# Altium schematic sheet POWER.SchDoc
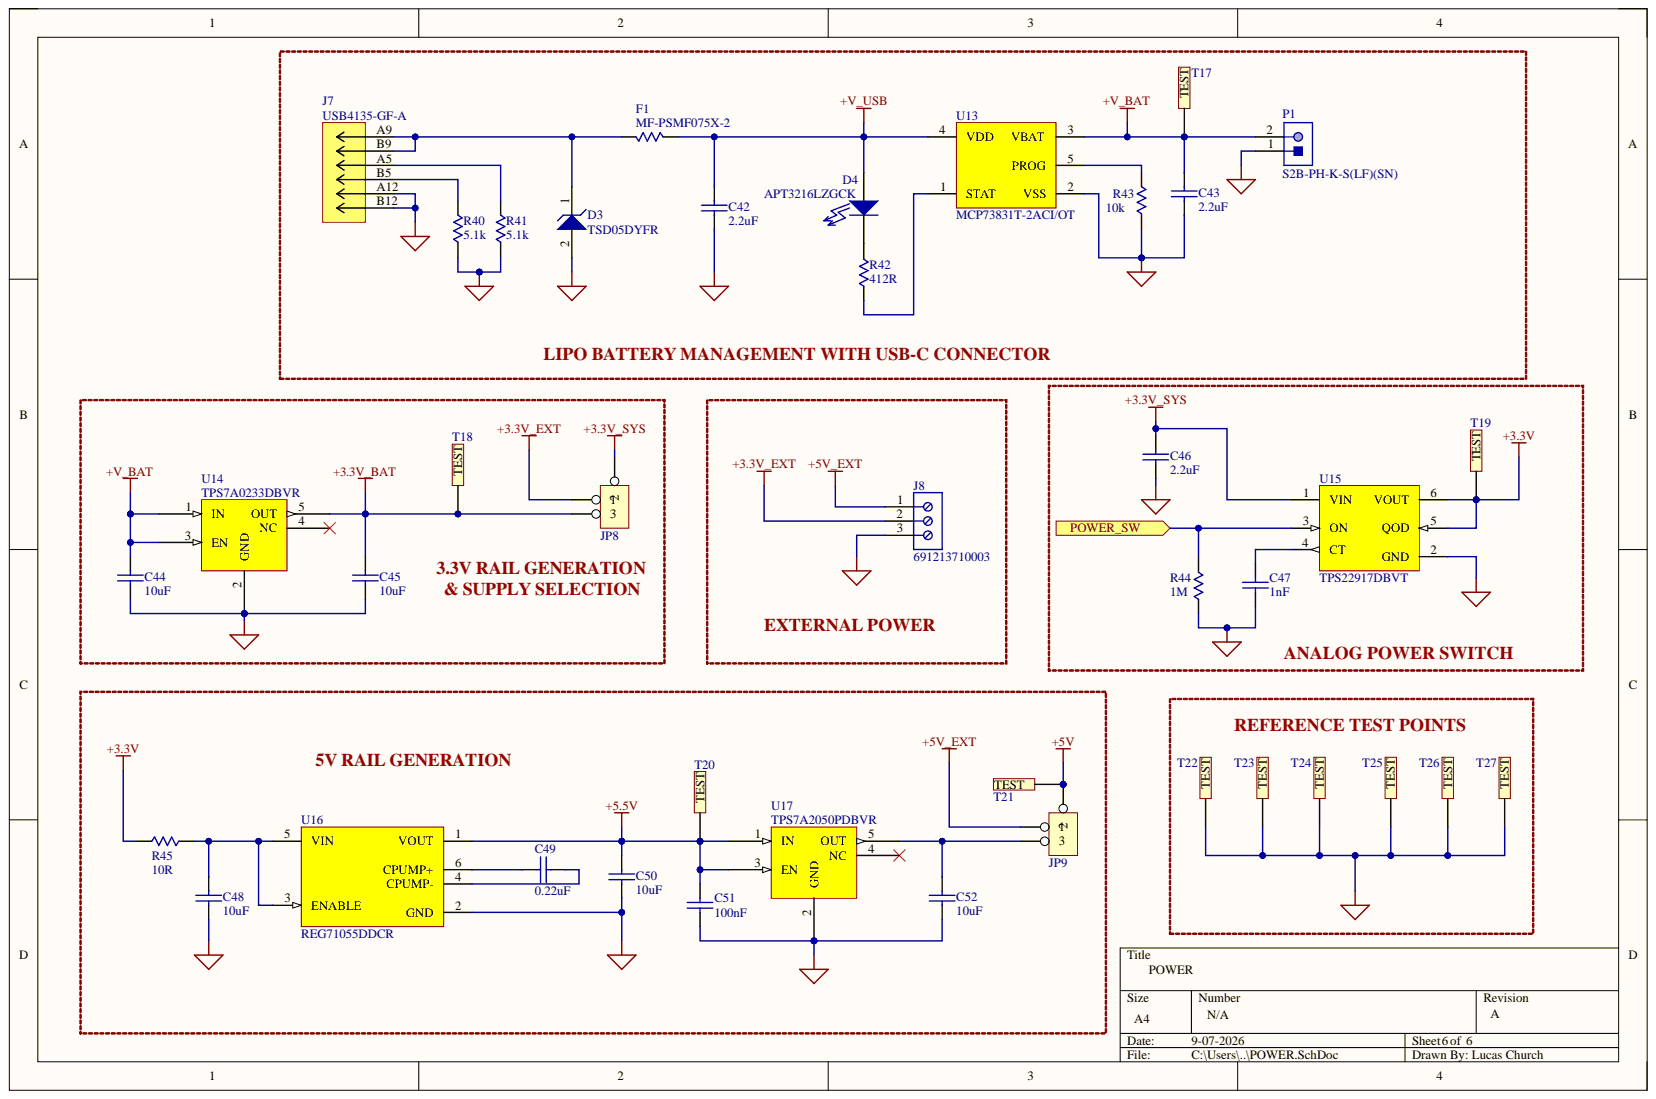
[diagram p0: POWER.SchDoc]

## Parts
C42  2.2uF  [0603-CAP]
C43  2.2uF  [0603-CAP]
C44  10uF  [0603-CAP]
C45  10uF  [0603-CAP]
C46  2.2uF  [0603-CAP]
C47  1nF  [0603-CAP]
C48  10uF  [0603-CAP]
C49  0.22uF  [0603-CAP]
C50  10uF  [0603-CAP]
C51  100nF  [0603-CAP]
C52  10uF  [0603-CAP]
D3  TSD05DYFR  [TVS_SOD2_DYF_TEX-L]
D4  APT3216LZGCK  [1206-LED]
F1  MF-PSMF075X-2  [0805-RES]
J7  USB4135-GF-A  [CONN_USB4135-GF-A_GCT]
J8  691213710003  [691213710003]
JP8  JUMPER1X3  [JUMPER3]  (value not drawn)
JP9  JUMPER1X3  [JUMPER3]  (value not drawn)
P1  S2B-PH-K-S(LF)(SN)  [JST-S2B-PH-K-S_V]
R40  5.1k  [0603-RES]
R41  5.1k  [0603-RES]
R42  412R  [0603-RES]
R43  10k  [0603-RES]
R44  1M  [0603-RES]
R45  10R  [0603-RES]
T17  5002K  [TESTPOINT White]  (value not drawn)
T18  5002K  [TESTPOINT White]  (value not drawn)
T19  5002K  [TESTPOINT White]  (value not drawn)
T20  5002K  [TESTPOINT White]  (value not drawn)
T21  5002K  [TESTPOINT White]  (value not drawn)
T22  5011K  [TESTPOINT Black]  (value not drawn)
T23  5011K  [TESTPOINT Black]  (value not drawn)
T24  5011K  [TESTPOINT Black]  (value not drawn)
T25  5011K  [TESTPOINT Black]  (value not drawn)
T26  5011K  [TESTPOINT Black]  (value not drawn)
T27  5011K  [TESTPOINT Black]  (value not drawn)
U13  MCP73831T-2ACI/OT  [SOT23_DBV_TEX-M]
U14  TPS7A0233DBVR  [SOT23_DBV_TEX-M]
U15  TPS22917DBVT  [DBV0006_N]
U16  REG71055DDCR  [DDC0006A_M]
U17  TPS7A2050PDBVR  [SOT23_DBV_TEX-M]

## Ports
POWER_SW

## Nets
+3.3V: R45.1 T19.1 U15.5(QOD) U15.6(VOUT)
+3.3V_BAT: C45.1 JP8.3 T18.1 U14.5(OUT)
+3.3V_EXT: J8.2 JP8.1
+3.3V_SYS: C46.1 JP8.2 U15.1(VIN)
+5.5V: C50.1 C51.1 T20.1 U16.1(VOUT) U17.1(IN) U17.3(EN)
+5V: JP9.2 T21.1
+5V_EXT: J8.1 JP9.1
+V_BAT: C43.1 C44.1 P1.2 T17.1 U13.3(VBAT) U14.1(IN) U14.3(EN)
+V_USB: C42.1 D4.1 F1.2 U13.4(VDD)
GND: C42.2 C43.2 C44.2 C45.2 C46.2 C47.2 C48.2 C50.2 C51.2 C52.2 D3.2 J7.A12 J7.B12 J8.3 P1.1 R40.2 R41.2 R43.2 R44.1 T22.1 T23.1 T24.1 T25.1 T26.1 T27.1 U13.2(VSS) U14.2(GND) U15.2(GND) U16.2(GND) U17.2(GND)
POWER_SW: R44.2 U15.3(ON) ; port POWER_SW
NetC47_1: C47.1 U15.4(CT)
NetC48_1: C48.1 R45.2 U16.3(ENABLE) U16.5(VIN)
NetC49_1: C49.1 U16.6(CPUMP+)
NetC49_2: C49.2 U16.4(CPUMP-)
NetC52_1: C52.1 JP9.3 U17.5(OUT)
NetD3_1: D3.1 F1.1 J7.A9 J7.B9
NetD4_2: D4.2 R42.2
NetJ7_A5: J7.A5 R41.1
NetJ7_B5: J7.B5 R40.1
NetR42_1: R42.1 U13.1(STAT)
NetR43_1: R43.1 U13.5(PROG)
NetU14_4: U14.4(NC)
NetU17_4: U17.4(NC)

## Library data of the parts
C42 C43 C46 = CGA3E2C0G1H472J080AA: CAP CER 2.2UF 35V X5R 0603; Manufacturer 1: Murata Electronics; Manufacturer Part Number 1: GRM188R6YA225MA12D; Database Table Name: Ceramic 0603$; Tech#: SMT; Component Kind: Standard; Detailed Description: CAP CER 2.2UF 35V X5R 0603; Price(100): 0.1434; Price(250): 0.10756; Supplier 1: DigiKey; Supplier 2: Mouser; Supplier Part Number 1: 490-10486-1-ND; Supplier Part Number 2: 810-CGA3E2C0G1H472J; Library Name: SGL_Capacitors.DBLib
C44 C45 = CGA3E2C0G1H472J080AA: CAP CER 10uF 6.3V X5R 0603; Manufacturer 1: Murata Electronics; Manufacturer Part Number 1: GRM188Z71A106KA73D; Database Table Name: Ceramic 0603$; Detailed Description: CAP CER 100 NF 50V X7R 0603; Tech#: SMT; Component Kind: Standard; Price(100): 0.1434; Price(250): 0.10756; Supplier 1: DigiKey; Supplier 2: Mouser; Supplier Part Number 1: 490-GRM188Z71A106KA73DCT-ND; Supplier Part Number 2: 810-CGA3E2C0G1H472J; Library Name: SGL_Capacitors.DBLib
C47 = CGA3E2C0G1H472J080AA: CAP CER 1000 PF 50V C0G 0603; Manufacturer 1: Murata; Manufacturer Part Number 1: GRM1885C1H102JA01D; Database Table Name: Ceramic 0603$; Detailed Description: CAP CER 100 NF 50V X7R 0603; Tech#: SMT; Component Kind: Standard; Price(100): 0.1434; Price(250): 0.10756; Supplier 1: DigiKey; Supplier 2: Mouser; Supplier Part Number 1: 490-1451-1-ND; Supplier Part Number 2: 810-CGA3E2C0G1H472J; Library Name: SGL_Capacitors.DBLib
C48 C52 = CGA3E2C0G1H472J080AA: CAP CER 10UF 10V X7R 0603; Manufacturer 1: Murata Electronics; Manufacturer Part Number 1: GRM188Z71A106KA73D; Database Table Name: Ceramic 0603$; Tech#: SMT; Component Kind: Standard; Detailed Description: CAP CER 10UF 10V X7R 0603; Price(100): 0.1434; Price(250): 0.10756; Supplier 1: DigiKey; Supplier 2: Mouser; Supplier Part Number 1: 490-GRM188Z71A106KA73DCT-ND; Supplier Part Number 2: 810-CGA3E2C0G1H472J; Library Name: SGL_Capacitors.DBLib
C49 = CGA3E2C0G1H472J080AA: CAP CER 0.22UF 25V X7R 0603; Manufacturer 1: Murata Electronics; Manufacturer Part Number 1: GRM188R71E224KA88D; Database Table Name: Ceramic 0603$; Tech#: SMT; Component Kind: Standard; Detailed Description: CAP CER 0.22UF 25V X7R 0603; Price(100): 0.1434; Price(250): 0.10756; Supplier 1: DigiKey; Supplier 2: Mouser; Supplier Part Number 1: 490-3290-1-ND; Supplier Part Number 2: 810-CGA3E2C0G1H472J; Library Name: SGL_Capacitors.DBLib
C50 = CGA3E2C0G1H472J080AA: CAP CER 10UF 10V X7R 0603; Manufacturer 1: Murata Electronics; Manufacturer Part Number 1: GRM188Z71A106KA73D; Database Table Name: Ceramic 0603$; Detailed Description: CAP CER 100 NF 50V X7R 0603; Tech#: SMT; Component Kind: Standard; Price(100): 0.1434; Price(250): 0.10756; Supplier 1: DigiKey; Supplier 2: Mouser; Supplier Part Number 1: 490-GRM188Z71A106KA73DCT-ND; Supplier Part Number 2: 810-CGA3E2C0G1H472J; Library Name: SGL_Capacitors.DBLib
C51 = CGA3E2C0G1H472J080AA: CAP CER 100 NF 50V X7R 0603; Manufacturer 1: YAGEO; Manufacturer Part Number 1: CC0603KRX7R9BB104; Database Table Name: Ceramic 0603$; Detailed Description: CAP CER 10UF 10V X7R 0603; Tech#: SMT; Component Kind: Standard; Price(100): 0.1434; Price(250): 0.10756; Supplier 1: DigiKey; Supplier 2: Mouser; Supplier Part Number 1: 311-1344-1-ND; Supplier Part Number 2: 810-CGA3E2C0G1H472J; Library Name: SGL_Capacitors.DBLib
D3 = TSD05DYFR: 5-V 60-A Unidirectional surge protection device 2-SOT -40 to 125; Manufacturer_Name: Texas Instruments; Component Kind: Standard; Manufacturer_Part_Number: TSD05DYFR; RefDes: RefDes; Type: Type; Copyright: Copyright (C) 2026 Ultra Librarian. All rights reserved.; Library Name: BioZ.SchLib
D4 = APT3216LZGCK: LED GREEN CLEAR 2SMD; Manufacturer 1: Kingbright; Database Table Name: LEDs$; Detailed Description: LED, Green SMT1206 2.65V 2mA 50mcd; Location: #N/A; Spec: 2.65V 2mA 50mcd; Tech#: SMT; Colour: Green; Component Kind: Standard; Manufacturer Part Number 1: APT3216LZGCK; Price(1): 0.94; Price(10): 0.725; Price(25): 0.6076; Price(100): 0.5233; Supplier 1: DigiKey; Supplier 2: Mouser; Supplier Part Number 1: 754-1944-1-ND; Supplier Part Number 2: 604-APT3216LZGCK; Library Name: SGL_Diodes.DBLib
F1 = 240R 0.1%: PTC RESET FUSE 6V 750MA 0805; Manufacturer 1: Bourns; Database Table Name: 0805-tenth%$; Tech#: SMT; Component Kind: Standard; Detailed Description: PTC RESET FUSE 6V 750MA 0805; Manufacturer Part Number 1: MF-PSMF075X-2; Price(100): 0.149; Simulation: Resistor; Supplier 1: Digikey; Supplier 2: DigiKey; Supplier Part Number 1: MF-PSMF075X-2CT-ND; Supplier Part Number 2: RG20P240BCT-ND; Library Name: SGL_Resistives.DBLib
J7 = USB4135-GF-A: Description; Manufacturer_Name: Global Connector Technology; Component Kind: Standard; Manufacturer_Part_Number: USB4135-GF-A; RefDes: RefDes; Type: Type; Copyright: Copyright (C) 2026 Ultra Librarian. All rights reserved.; Library Name: BioZ.SchLib
J8 = CMP-1502-02527-3: 213 Series 5MM Pitch Straight, Pcb Terminal Block, Through Hole, 3 Way; Connector Type: PCB, Terminal Block; Current: 10A; Number of Contacts: 3; Number of Levels: 1; Pitch: 5.0038mm; Plating: Brass, Steel; Stripping Length: -7mm; Voltage: 300V; Wire Gauge (Max): 26AWG; Wire Gauge (Min): 16AWG; Color: Green; Component Kind: Standard; Contact Material: Brass; Contact Plating: Tin; Height: 10mm; Housing Material: Nylon; Lead Pitch: 5mm; Length: 8.3mm; Library Name: Altium Content Vault; Max Operating Temperature: 105°C; Min Operating Temperature: -40°C; Mount: Through Hole; Number of Pins: 3; Number of Positions Per Level: 3; Packaging: Box; REACH SVHC: Unknown; RoHS: Compliant; Termination: Screw; Width: 15.6mm; Wire/Cable Gauge: 16AWG
JP8 JP9 = 68001-203HLF: CONN HEADER VERT 36POS 2.54MM; Manufacturer 1: FCI; Database Table Name: Jumpers$; Detailed Description: Jumper, 1x3 Vertical T/H 0.1in; Location: #N/A; Notes: Can be 68001-236HLF cut to length; Tech#: T/H; Component Kind: Standard; Manufacturer Part Number 1: 68001-203HLF; Price(1): 0.43; Price(10): 0.32; Price(25): 0.32; Price(100): 0.2436; Supplier 1: DigiKey; Supplier 2: Mouser; Supplier Part Number 1: 609-3470-ND; Supplier Part Number 2: 649-68001-203HLF; Library Name: SGL_Connectors.DBLib
P1 = CMP-1755-00004-1: Male Header, Pitch 2 mm, 1 x 2 Position, Height 4.8 mm, Tail Length 3.4 mm, -25 to 85 degC, RoHS, Bulk; Capacitance: 10 uF; Case\Package: Radial; Tolerance: 20%; Voltage Rating: 50 V; Component Kind: Standard; ComponentLink1Description: Datasheet; ComponentLink1URL: http://www.jst-mfg.com/product/pdf/eng/ePH.pdf; DatasheetVersion: Dec-12; Diameter: 5 mm; Height: 11 mm; Lead Pitch: 2 mm; Length: 11 mm; Library Name: Altium Content Vault; Max Operating Temperature: 85 degC; Min Operating Temperature: -40 degC; Mount: Through Hole; Package Quantity: 200; PackageDescription: Male Header, Pitch 2 mm, 1 x 2 Position, Height 4.8 mm, Tail Length 3.4 mm; PackageReference: S2B-PH-K-S; Packaging: Bulk; Pins: 2; Radiation Hardening: No; REACH SVHC: No SVHC; RoHSCompliant: true; Voltage Rating (DC): 50 V
R40 R41 = 4.99K 0603: RES SMD 5.1K OHM 0.1% 1/10W 0603; Manufacturer 1: Susumu; Manufacturer Part Number 1: RG1608P-512-B-T5; Database Table Name: 0603$; Tech#: SMT; Component Kind: Standard; Detailed Description: RES SMD 5.1K OHM 0.1% 1/10W 0603; Price(100): 0.023; Simulation: Resistor; Supplier 1: DigiKey; Supplier 2: Mouser; Supplier Part Number 1: RG16P5.1KBCT-ND; Supplier Part Number 2: 754-RR0816P-4991D68H; Library Name: SGL_Resistives.DBLib
R42 = 4.99K 0603: RES SMD 412OHM 0.1% 1/10W 0603; Manufacturer 1: YAGEO; Manufacturer Part Number 1: RT0603BRD07412RL; Database Table Name: 0603$; Tech#: SMT; Component Kind: Standard; Detailed Description: RES SMD 412OHM 0.1% 1/10W 0603; Price(100): 0.023; Simulation: Resistor; Supplier 1: DigiKey; Supplier 2: Mouser; Supplier Part Number 1: YAG1659CT-ND; Supplier Part Number 2: 754-RR0816P-4991D68H; Library Name: SGL_Resistives.DBLib
R43 = 4.99K 0603: RES SMD 10K OHM 1% 1/10W 0603; Manufacturer 1: Panasonic Industry; Manufacturer Part Number 1: ERJ-3EKF1002V; Database Table Name: 0603$; Tech#: SMT; Component Kind: Standard; Detailed Description: RES SMD 10K OHM 1% 1/10W 0603; Price(100): 0.023; Simulation: Resistor; Supplier 1: DigiKey; Supplier 2: Mouser; Supplier Part Number 1: P10.0KHCT-ND; Supplier Part Number 2: 754-RR0816P-4991D68H; Library Name: SGL_Resistives.DBLib
R44 = 4.99K 0603: RES SMD 1MOHM 0.1% 1/10W 0603; Manufacturer 1: YAGEO; Manufacturer Part Number 1: RT0603BRD071ML; Database Table Name: 0603$; Detailed Description: RES SMD 1OHM 0.1% 1/10W 0603; Tech#: SMT; Component Kind: Standard; Price(100): 0.023; Simulation: Resistor; Supplier 1: DigiKey; Supplier 2: Mouser; Supplier Part Number 1: YAG4498CT-ND; Supplier Part Number 2: 754-RR0816P-4991D68H; Library Name: SGL_Resistives.DBLib
R45 = 4.99K 0603: RES SMD 10OHM 0.05% 1/10W 0603; Manufacturer 1: Stackpole Electronics; Manufacturer Part Number 1: RNCF0603AKT10R0; Database Table Name: 0603$; Tech#: SMT; Component Kind: Standard; Detailed Description: RES SMD 10OHM 0.05% 1/10W 0603; Price(100): 0.023; Simulation: Resistor; Supplier 1: DigiKey; Supplier 2: Mouser; Supplier Part Number 1: RNCF0603AKT10R0CT-ND; Supplier Part Number 2: 754-RR0816P-4991D68H; Library Name: SGL_Resistives.DBLib
T17-T21 = 5002K: PC TEST POINT MINIATURE WHITE; Manufacturer 1: Keystone Electronics; Database Table Name: Testpoints$; Detailed Description: Connector, TestPoint White; Location: F1-33; Tech#: T/H; Component Kind: Standard; Manufacturer Part Number 1: 5002K; Price(1): 0.56; Price(10): 0.506; Price(100): 0.3541; Supplier 1: DigiKey; Supplier 2: Mouser; Supplier Part Number 1: 36-5002-ND; Supplier Part Number 2: 534-5002; Library Name: SGL_Connectors.DBLib
T22-T27 = 5011K: PC TEST POINT MULTIPURPOSE BLACK; Manufacturer 1: Keystone Electronics; Database Table Name: Testpoints$; Detailed Description: Connector, TestPoint Black; Location: F1-34; Tech#: T/H; Component Kind: Standard; Manufacturer Part Number 1: 5011K; Price(1): 0.57; Price(10): 0.506; Price(100): 0.3541; Supplier 1: DigiKey; Supplier 2: Mouser; Supplier Part Number 1: 36-5011-ND; Supplier Part Number 2: 534-5011; Library Name: SGL_Connectors.DBLib
U13 = CMP-0133-00143-2: IC CONTROLLR LI-ION 4.2V SOT23-5; Drain to Source Resistance: 350mR; Max Charge Current: 500mA; Max Input Voltage: 6V; Max Output Voltage: 4.5V; Max Power Dissipation: 1.54W; Min Output Voltage: 4.168V; Min Supply Voltage: 3.75V; Number of Cells: 1; Voltage Rating: 4.534V; Case/Package: SOT-23; Component Kind: Standard; Contact Plating: Tin; Height: 0.051inch; Lead Free: Lead Free; Length: 0.122inch; Max Operating Temperature: 85°C; Max Supply Voltage: 6V; Min Operating Temperature: -40°C; Mount: Surface Mount; Number of Channels: 1; Number of Outputs: 1; Number of Pins: 5; Output Current: 500mA; Output Voltage: 4.2V; Packaging: Tape and Reel; Radiation Hardening: No; REACH SVHC: No SVHC; Termination: SMD/SMT; Weight: 0.00709oz; Width: 0.071inch; Library Name: BioZ.SchLib
U14 U17 = TPS7A0230PDBVR: 200mA, nanopower-IQ (25 nA), low-dropout (LDO) voltage regulator with enable 5-SOT-23 -40 to 125; Manufacturer_Name: Texas Instruments; Component Kind: Standard; RefDes: RefDes; Type: Type; Copyright: Copyright (C) 2026 Ultra Librarian. All rights reserved.; Library Name: BioZ.SchLib
  U14: Manufacturer_Part_Number: TPS7A0233DBVR
  U17: Manufacturer_Part_Number: TPS7A2050PDBVR
U15 = TPS22917DBVT: 5.5-V, 2-A, 80-mÎ© , 10-nA leakage load switch adj. rise time and adj output discharge 6-SOT-23 -40 to 125; Manufacturer_Name: Texas Instruments; Component Kind: Standard; Manufacturer_Part_Number: TPS22917DBVT; RefDes: RefDes; TYPE: TYPE; Copyright: Copyright (C) 2026 Ultra Librarian. All rights reserved.; Library Name: BioZ.SchLib
U16 = CMP-0391-00039-2: 5.5 V, Buck / Boost Charge Pump, 60 mA, 3 to 5.5 V Input with 2-Cell Alkaline / Nixx Input, -40 to 85 degC, 6-pin SOT23 (DDC6), Green (RoHS & no Sb/Br); Manufacturer: Texas Instruments; 2-Cell Alkaline/Nixx Input: TRUE; Efficiency(Typ)(%): 90; Iout(Max)(A): 0.06; Iq(Typ)(mA): 0.065; Preset Vout(V): 5.5; Switching Frequency(Max)(kHz): 1000; Vin(Min)(V): 3; Vout(Max)(V): 5.8; Vout(Min)(V): 5.2; Code_JEDEC: MO-193; Component Kind: Standard; ComponentLink1Description: Manufacturer URL; ComponentLink1URL: http://www.ti.com/; ComponentLink2Description: Datasheet; ComponentLink2URL: http://www.ti.com/general/docs/lit/getliterature.tsp?genericPartNumber=REG71055&fileType=pdf; ComponentLink3Description: Package Specification; ComponentLink3URL: http://www.ti.com/litv/pdf/mpds124d; DatasheetVersion: SBAS221G; Eco-Plan: Green (RoHS & no Sb/Br); Mounting Technology: Surface Mount; PackageDescription: 6-Pin Plastic Small Outline, Body 2.9 x 1.6 mm, 0.95 mm Pitch; PackageReference: DDC0006A; PackageVersion: 4204403-3/E; PartNumber: REG71055DDCR; Topology: Buck/Boost; Vin(Max)(V): 5.5; Library Name: BioZ.SchLib
